# Altium schematic sheet Schematics.SchDoc
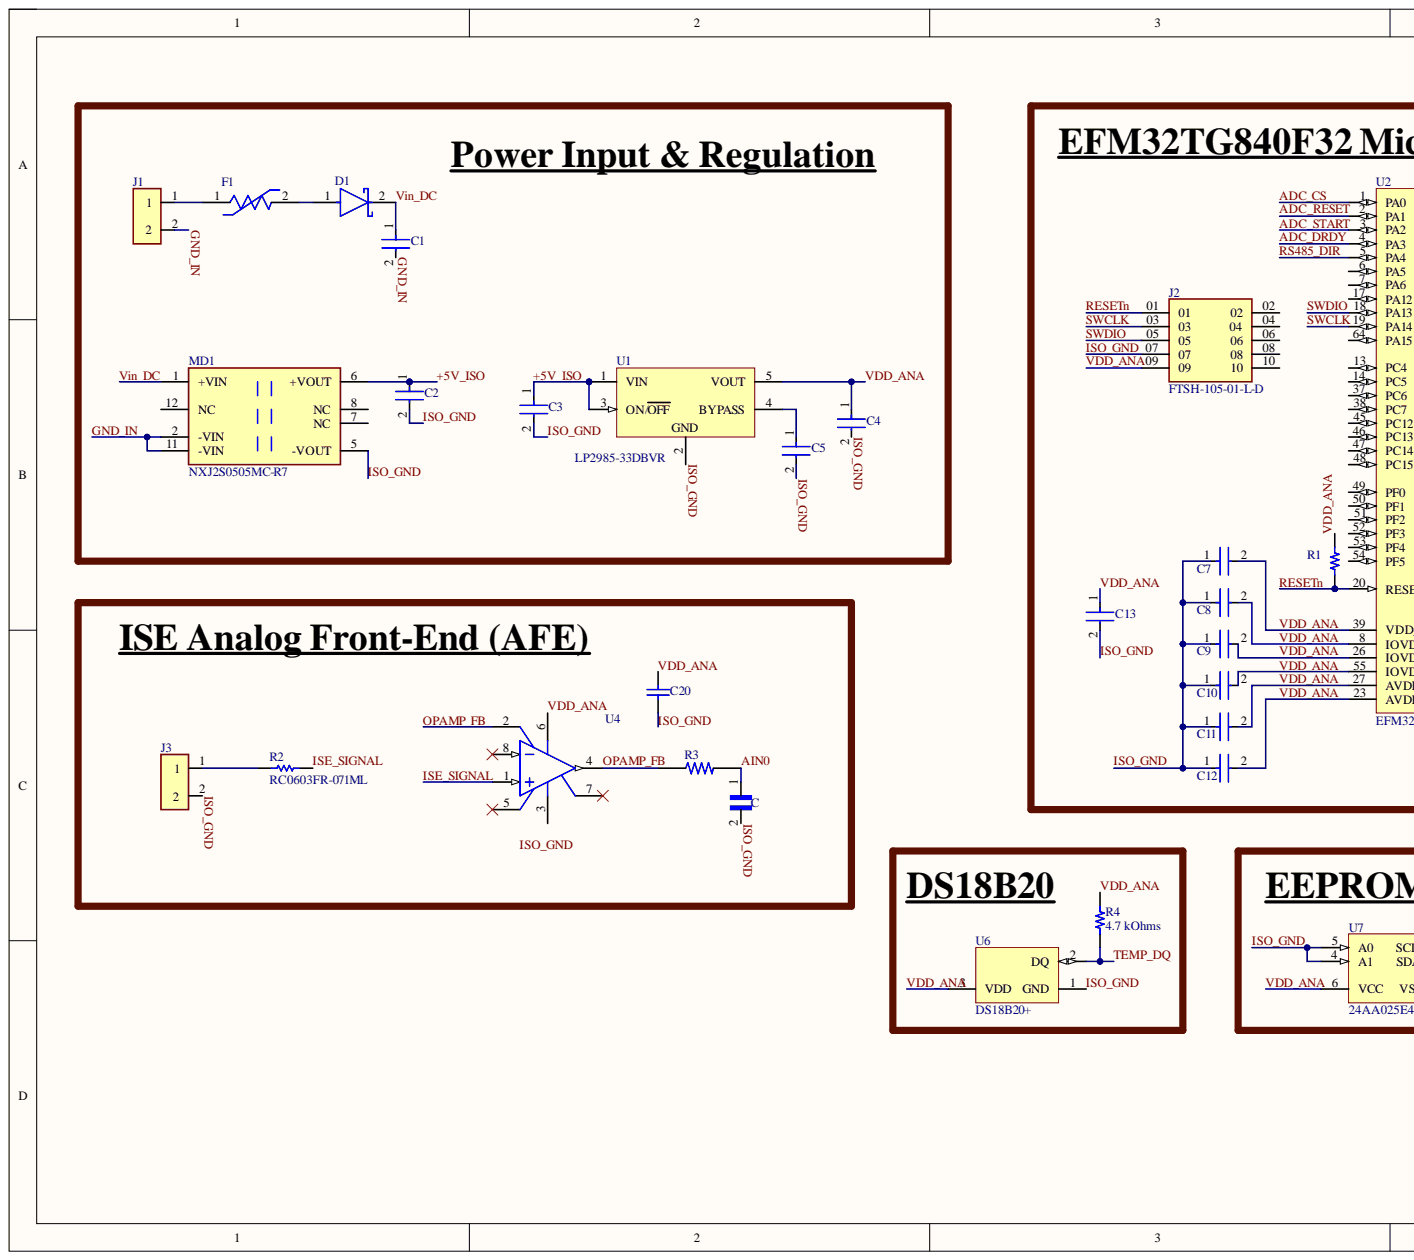
[diagram p0: Schematics.SchDoc, left]
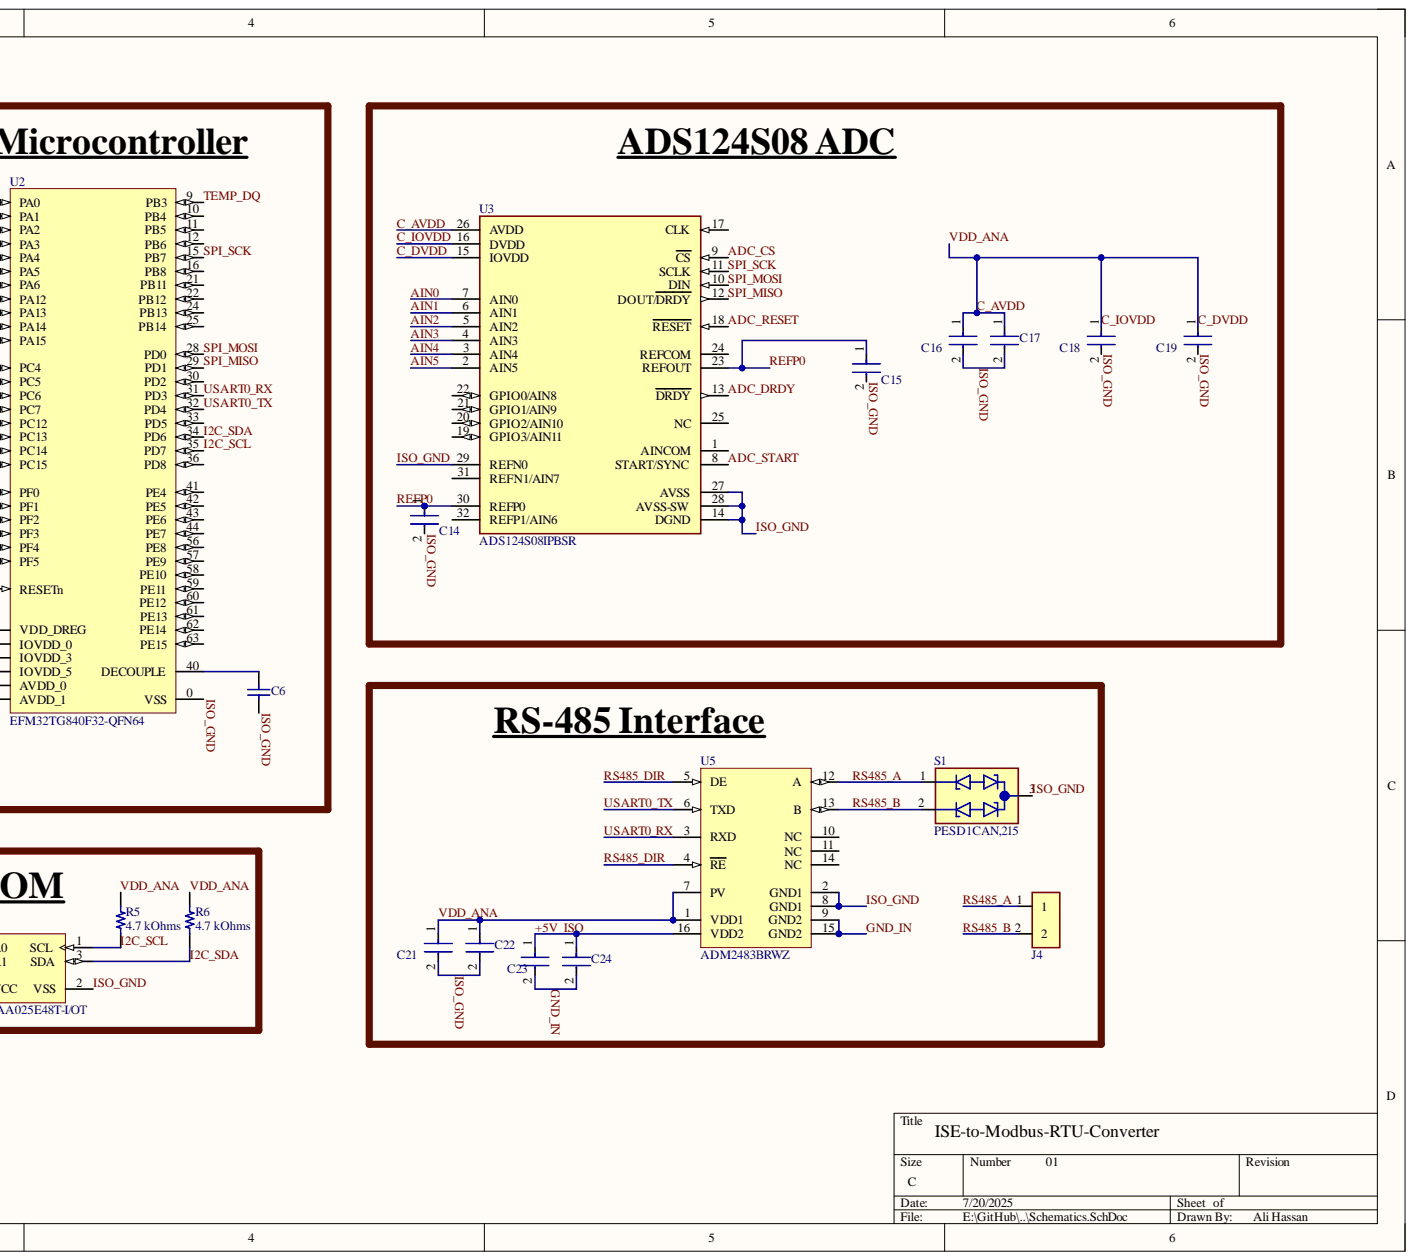
[diagram p1: Schematics.SchDoc, right]

## Parts
C  CL10B104KO8NNNC  [CAPC1608X90N]  (value not drawn)
C1  GRM188R60J106ME47D  [FP-GRM188-0_1-e0_2_0_55-IPC_A]  (value not drawn)
C2  GRM188R60J106ME47D  [FP-GRM188-0_1-e0_2_0_55-IPC_A]  (value not drawn)
C3  GRM188R60J106ME47D  [FP-GRM188-0_1-e0_2_0_55-IPC_A]  (value not drawn)
C4  GRM188R60J106ME47D  [FP-GRM188-0_1-e0_2_0_55-IPC_A]  (value not drawn)
C5  GRM188R60J106ME47D  [FP-GRM188-0_1-e0_2_0_55-IPC_A]  (value not drawn)
C6  C0805C105K4PACTU  [CAPC2013X145X50LL20T25]  (value not drawn)
C7  C1005X7R1H104K050BB  [FP-C1005-050-0_05-IPC_C]  (value not drawn)
C8  C1005X7R1H104K050BB  [FP-C1005-050-0_05-IPC_C]  (value not drawn)
C9  C1005X7R1H104K050BB  [FP-C1005-050-0_05-IPC_C]  (value not drawn)
C10  C1005X7R1H104K050BB  [FP-C1005-050-0_05-IPC_C]  (value not drawn)
C11  C1005X7R1H104K050BB  [FP-C1005-050-0_05-IPC_C]  (value not drawn)
C12  C1005X7R1H104K050BB  [FP-C1005-050-0_05-IPC_C]  (value not drawn)
C13  GRM188R60J106ME47D  [FP-GRM188-0_1-e0_2_0_55-IPC_A]  (value not drawn)
C14  C1005X7R1H104K050BB  [FP-C1005-050-0_05-IPC_C]  (value not drawn)
C15  C1005X7R1H104K050BB  [FP-C1005-050-0_05-IPC_C]  (value not drawn)
C16  C1005X7R1H104K050BB  [FP-C1005-050-0_05-IPC_C]  (value not drawn)
C17  GRM188R60J106ME47D  [FP-GRM188-0_1-e0_2_0_55-IPC_A]  (value not drawn)
C18  C1005X7R1H104K050BB  [FP-C1005-050-0_05-IPC_C]  (value not drawn)
C19  C1005X7R1H104K050BB  [FP-C1005-050-0_05-IPC_C]  (value not drawn)
C20  GRM188R71C104KA01D  [MURA-0603_H_0.9_M]  (value not drawn)
C21  C1005X7R1H104K050BB  [FP-C1005-050-0_05-IPC_C]  (value not drawn)
C22  GRM188R60J106ME47D  [FP-GRM188-0_1-e0_2_0_55-IPC_A]  (value not drawn)
C23  C1005X7R1H104K050BB  [FP-C1005-050-0_05-IPC_C]  (value not drawn)
C24  GRM188R60J106ME47D  [FP-GRM188-0_1-e0_2_0_55-IPC_A]  (value not drawn)
D1  SS14  [FP-403AE-MFG]  (value not drawn)
F1  1206L050YR  [FP-1206L050YR-MFG]  (value not drawn)
J1  OSTTC020162  [OST_OSTTC020162]  (value not drawn)
J2  FTSH-105-01-L-D  [SAMTEC_FTSH-105-01-L-D]
J3  OSTTC020162  [OST_OSTTC020162]  (value not drawn)
J4  OSTTC020162  [OST_OSTTC020162]  (value not drawn)
MD1  NXJ2S0505MC-R7  [FP-NXJ2SM-MFG]
R1  10 kOhms  [YAGEO RES 0603_1608]  (value not drawn)
R2  RC0603FR-071ML  [RESC1608X55X25LL10T15]
R3  RC0603FR-07100RL  [RESC1607X60N]  (value not drawn)
R4  4.7 kOhms  [YAGEO RES 0603_1608]
R5  4.7 kOhms  [YAGEO RES 0603_1608]
R6  4.7 kOhms  [YAGEO RES 0603_1608]
S1  PESD1CAN,215  [DIO_PESD1CAN,215]
U1  LP2985-33DBVR  [DBV0005A_M]
U2  EFM32TG840F32-QFN64  [ENMR-QFN64_N]
U3  ADS124S08IPBSR  [FP-PBS0032A-MFG]
U4  LMP7721MA/NOPB  [D0008A_M]  (value not drawn)
U5  ADM2483BRWZ  [ADI-ADM2483BRWZ-16_M]
U6  DS18B20+  [Q3+1]
U7  24AA025E48T-I/OT  [MCHP-SOT-23-OT6_L]

## Nets
+5V_ISO: C2.1 C3.1 C23.1 C24.1 MD1.6(+VOUT) U1.1(VIN) U1.3(ON/OFF) U5.16(VDD2)
ADC_CS: U2.1(PA0) U3.9(CS)
ADC_DRDY: U2.4(PA3) U3.13(DRDY)
ADC_RESET: U2.2(PA1) U3.18(RESET)
ADC_START: U2.3(PA2) U3.8(START/SYNC)
AIN0: C.1 R3.2 U3.7(AIN0)
AIN1: U3.6(AIN1)
AIN2: U3.5(AIN2)
AIN3: U3.4(AIN3)
AIN4: U3.3(AIN4)
AIN5: U3.2(AIN5)
C_AVDD: C4.1 C7.2 C8.2 C9.2 C10.2 C11.2 C12.2 C13.1 C16.1 C17.1 C18.1 C19.1 C20.2 C21.1 C22.1 J2.09 R1.2 R4.2 R5.2 R6.2 U1.5(VOUT) U2.8(IOVDD_0) U2.23(AVDD_1) U2.26(IOVDD_3) U2.27(AVDD_0) U2.39(VDD_DREG) U2.55(IOVDD_5) U3.15(IOVDD) U3.16(DVDD) U3.26(AVDD) U4.6 U5.1(VDD1) U5.7(PV) U6.3 U7.6(VCC)
GND_IN: C1.2 C23.2 C24.2 J1.2 MD1.2(-VIN) MD1.11(-VIN) U5.9(GND2) U5.15(GND2)
I2C_SCL: R5.1 U2.35(PD7) U7.1(SCL)
I2C_SDA: R6.1 U2.34(PD6) U7.3(SDA)
ISE_SIGNAL: R2.2 U4.1
ISO_GND: C.2 C2.2 C3.2 C4.2 C5.2 C6.1 C7.1 C8.1 C9.1 C10.1 C11.1 C12.1 C13.2 C14.2 C15.2 C16.2 C17.2 C18.2 C19.2 C20.1 C21.2 C22.2 J2.07 J3.2 MD1.5(-VOUT) S1.3 U1.2 U2.0(VSS) U3.14(DGND) U3.27(AVSS) U3.28(AVSS-SW) U3.29(REFN0) U5.2(GND1) U5.8(GND1) U6.1 U7.2(VSS) U7.4(A1) U7.5(A0)
OPAMP_FB: R3.1 U4.2 U4.4
REFP0: C14.1 C15.1 U3.23(REFOUT) U3.30(REFP0)
RESETn: J2.01 R1.1 U2.20(RESETn)
RS485_A: J4.1 S1.1 U5.12(A)
RS485_B: J4.2 S1.2 U5.13(B)
RS485_DIR: U2.5(PA4) U5.4(RE) U5.5(DE)
SPI_MISO: U2.29(PD1) U3.12(DOUT/DRDY)
SPI_MOSI: U2.28(PD0) U3.10(DIN)
SPI_SCK: U2.15(PB7) U3.11(SCLK)
SWCLK: J2.03 U2.19(PA14)
SWDIO: J2.05 U2.18(PA13)
TEMP_DQ: R4.1 U2.9(PB3) U6.2
USART0_RX: U2.31(PD3) U5.3(RXD)
USART0_TX: U2.32(PD4) U5.6(TXD)
Vin_DC: C1.1 D1.2 MD1.1(+VIN)
NetC5_1: C5.1 U1.4(BYPASS)
NetC6_2: C6.2 U2.40(DECOUPLE)
NetD1_1: D1.1 F1.2
NetF1_1: F1.1 J1.1
NetJ3_1: J3.1 R2.1

Not connected: J2 02 04 06 08 10; MD1 7(NC) 8(NC) 12(NC); U2 6(PA5) 7(PA6) 10(PB4) 11(PB5) 12(PB6) 13(PC4) 14(PC5) 16(PB8) 17(PA12) 21(PB11) 22(PB12) 24(PB13) 25(PB14) 30(PD2) 33(PD5) 36(PD8) 37(PC6) 38(PC7) 41(PE4) 42(PE5) 43(PE6) 44(PE7) 45(PC12) 46(PC13) 47(PC14) 48(PC15) 49(PF0) 50(PF1) 51(PF2) 52(PF3) 53(PF4) 54(PF5) 56(PE8) 57(PE9) 58(PE10) 59(PE11) 60(PE12) 61(PE13) 62(PE14) 63(PE15) 64(PA15); U3 1(AINCOM) 17(CLK) 19(GPIO3/AIN11) 20(GPIO2/AIN10) 21(GPIO1/AIN9) 22(GPIO0/AIN8) 24(REFCOM) 25(NC) 31(REFN1/AIN7) 32(REFP1/AIN6); U4 3 5 7 8; U5 10(NC) 11(NC) 14(NC)

## Library data of the parts
C = CL10B104KO8NNNC: 0.1ÂµF Â±10% 16V Ceramic Capacitor X7R 0603 _1608 Metric_; MF: Samsung Electro-Mechanics; Availability: Unavailable; Component Kind: Standard; Library Name: CL10B104KO8NNNC.IntLib; MP: CL10B104KO8NNNC; Package: 0603 Samsung; Price: None; SnapEDA_Link: https://www.snapeda.com/parts/CL10B104KO8NNNC/Samsung%20Electro-Mechanics/view-part/3598551/?ref=snap
C1-C5 C13 C17 C22 C24 = CMP-2006-02792-2: Chip Multilayer Ceramic Capacitors for General Purpose, 0603, 10uF, X5R, 15%, 20%, 6.3V; Capacitance: 10uF; Case Code (Imperial): 0603; Case Code (Metric): 1608; Case/Package: 0603; Component Kind: Standard; Depth: 0.8mm; Dielectric: X5R; Height: 0.8mm; Lead Free: Lead Free; Length: 1.6mm; Library Name: Altium Content Vault; Material: Ceramic; Max Operating Temperature: 85°C; Min Operating Temperature: -55°C; Mount: Surface Mount; Package Quantity: 4000; Packaging: Tape and Reel; Radiation Hardening: No; REACH SVHC: No SVHC; Termination: SMD/SMT; Thickness: 0.035inch; Tolerance: 20%; Voltage: 6.3V; Voltage Rating: 6.3V; Voltage Rating (DC): 6.3V; Width: 0.031inch
C6 = CMP-1036-04746-2: Manufacturer: Kemet; Component Kind: Standard; Library Name: Altium Content Vault; Part Number: C0805C105K4PACTU
C7-C12 C14-C16 C18 C19 C21 C23 = CMP-2000-05393-2: Multilayer Ceramic Capacitors 100nF ±10% 50V X7R SMD 0402; Capacitance: 100nF; Case Code (Imperial): 0402; Case Code (Metric): 1005; Case/Package: 0402; Component Kind: Standard; Construction: Flat; Depth: 0.5mm; Dielectric: X7R; Dielectric Material: Ceramic; Height: 0.5mm; Lead Free: Lead Free; Length: 1mm; Library Name: Altium Content Vault; Max Operating Temperature: 125°C; Min Operating Temperature: -55°C; Mount: Surface Mount; Package Quantity: 1; Packaging: Tape and Reel; Radiation Hardening: No; REACH SVHC: No SVHC; Resistor Type: Ceramic; RoHS Compliant: Yes; Series: C; Termination: SMD/SMT; Thickness: 0.022inch; Tolerance: 10%; Voltage: 50V; Voltage Rating: 50V; Voltage Rating (DC): 50V; Weight: 2.3E-05oz; Width: 0.02inch
C20 = CMP-2000-05253-1: CAP, Ceramic, 0.1 uF, 10%, 16 V, 0603, RoHS, Tape and Reel; Manufacturer: Murata; Brand: MurataElectronics; Capacitance: 0.1uF; Capacitance - nF: 100nF; Capacitance - pF: 100000pF; Case Height: 0.8mm; Case Length: 1.6mm; Dielectric: X7R; Temperature Coefficient / Code: +/-15%; Type: GeneralPurposeMLCC; Voltage Rating: 16V; Case Code - in: 0603; Case Code - mm: 1608; Case Width: 0.8mm; Component Kind: Standard; Datasheet URL: https://datasheet.ciiva.com/29202/451467-da-01-en-vs-kondensator-0603-29202287.pdf; Datasheet Version: Dec-10; Dimensions: 0.8mmWx1.6mmLx0.8mmH; Factory Pack Quantity: 4000; Library Name: Altium Content Vault; Manufacturer URL: http://www.murata.com/; Maximum Operating Temperature: +125C; Minimum Operating Temperature: -55C; Operating Temperature Range: -55Cto+125C; Package / Case: 0603(1608metric); Packaging: Reel; Product: GeneralTypeMLCCs; Product Category: MultilayerCeramicCapacitorsMLCC-SMD/SMT; RoHS: Details; Series: GRM; Termination Style: SMD/SMT; Tolerance: 10%; Voltage Rating DC: 16V
D1 = CMP-2000-04943-2: DIODE SCHOTTKY 40V 1A SMA; Manufacturer: ON Semiconductor; Max Junction Temp: +125°C; Max Surge Forward Current: 40A; Peak Reverse Current: 0.2mA; Standoff Height: 0.05mm; Automotive: No; Category: Diode; Component Kind: Standard; ComponentLink2Description: Datasheet; ComponentLink2URL: https://www.onsemi.com/pub/Collateral/SS19-D.PDF; Device Class L1: Discrete Semiconductors; Device Class L2: Diodes; Device Class L3: Schottky Diodes; Digikey Description: DIODE SCHOTTKY 40V 1A SMA; Forward Current: 1A; Height: 2.5mm; Lead Free: Yes; Library Name: Altium Content Vault; Manufacturer Part Number: SS14; Max Repetitive Reverse Voltage: 40V; Mouser Description: Schottky Diodes & Rectifiers SMA 40V 1.0A; Octopart: https://octopart.com/ss14-on+semiconductor-29205033; Package: 403AE; RoHS: TRUE; Temperature: -65°C to +125°C; Temperature Range High: +125°C; Temperature Range Low: -65°C
F1 = CMP-08606-000073-1: PTC RESET FUSE 6V 500MA 1206; Approvals: cULus; Current Rating: 100A; Max Power Dissipation: 600mW; Resistance: 700mR; Time to Trip: 0.1s; Trip Current: 1A; Case Code (Imperial): 1206; Case Code (Metric): 3216; Case/Package: 1206; Component Kind: Standard; Height: 0.75mm; Hold Current: 500mA; Lead Free: Lead Free; Length: 3.4mm; Library Name: Altium Content Vault; Max Current Rating: 100A; Max Operating Temperature: 85°C; Max Voltage Rating (DC): 6V; Min Operating Temperature: -40°C; Operating Supply Voltage: 6V; Package Quantity: 4000; Packaging: Tape and Reel; Power Dissipation: 600mW; REACH SVHC: No SVHC; RoHS Compliant: Yes; Series: 1206L; Terminal Material: Copper; Termination: SMD/SMT; Voltage Rating: 6V; Voltage Rating (DC): 6V; Width: 1.8mm; Working Voltage: 6V
J1 J3 J4 = OSTTC020162: 2 Position Wire to Board Terminal Block Horizontal with Board 0.197 _5.00mm_ Through Hole; MF: On Shore; Availability: Unavailable; Component Kind: Standard; Library Name: OSTTC020162.IntLib; MP: OSTTC020162; Package: None; Price: None; SnapEDA_Link: https://www.snapeda.com/parts/OSTTC020162/On%20Shore%20Technology%20Inc./view-part/13090/?ref=snap
J2 = FTSH-105-01-L-D: MF: Samtec; Availability: Unavailable; Component Kind: Standard; Library Name: FTSH-105-01-L-D.IntLib; MP: FTSH-105-01-L-D; Package: None; Price: None
MD1 = CMP-20596-000009-1: DC DC CONVERTER 5V 2W; Component Kind: Standard; Library Name: Altium Content Vault; RoHS Compliant: Yes
R1 = AA0603FR-0710KL: RES SMD 10K OHM 1% 1/10W 0603; Manufacturer: Yageo; Database Table Name: Resistors - Surface Mount - 0603; Power (Watts): 0.1W, 1/10W; Temperature Coefficient: ±150ppm/°C; Component Kind: Standard; ComponentLink1Description: Datasheet; ComponentLink1URL: https://www.yageo.com/upload/media/product/productsearch/datasheet/rchip/PYu-AA_51_RoHS_L_3.pdf; ComponentLink2Description: DigiKey Link; ComponentLink2URL: https://www.digikey.com/en/products/detail/yageo/AA0603FR-0710KL/5888698; Composition: Thick Film; Device Package: 0603 (1608 Metric); Features: Anti-Sulfur, Automotive AEC-Q200, Moisture Resistant; Height - Seated (Max): 0.022" (0.55mm); LastUpdated: 25/07/2024 9:19:15 am; Library Name: Celestial Altium Library - altium_library.DbLib; Lifecycle Status: Active; Minimum Order: 1; Number of Terminations: 2; Operating Temperature: -55°C ~ 155°C; Package / Case: 0603 (1608 Metric); Packaging: TapeAndReel; Part Number: AA0603FR-0710KL; Part Status: Active; PartId: 64302; Price: 0.01; Ratings: AEC-Q200; Resistance: 10 kOhms; Series: AA; Size / Dimension: 0.063" L x 0.031" W (1.60mm x 0.80mm); Supplier 1: DigiKey; Supplier Device Package: 0603; Supplier Part Number 1: 13-AA0603FR-0710KLCT-ND; Tolerance: ±1%; Value: 10 kOhms
R2 = CMP-1659-00056-1: Chip Resistor, 1 MOhm, +/- 1%, 100 mW, -55 to 155 degC, 0603 (1608 Metric), RoHS, Tape and Reel; Capacitance: 1 mF; Case\Package: Radial; Tolerance: 20%; Voltage Rating: 25 V; Component Kind: Standard; ComponentLink1Description: Manufacturer URL; ComponentLink1URL: http://www.yageo.com/; ComponentLink2Description: Datasheet; ComponentLink2URL: http://www.yageo.com/documents/recent/PYu-RC_Group_51_RoHS_L_04.pdf; DatasheetVersion: Rev. 4, 02/2016; Diameter: 10 mm; Height: 20 mm; Lead Pitch: 5 mm; Length: 20 mm; Library Name: Altium Content Vault; Max Operating Temperature: 85 degC; Min Operating Temperature: -40 degC; Mount: Through Hole; Package Quantity: 200; PackageDescription: 2-Pin Surface Mount Device, Body 1.6 x 0.8 mm; PackageReference: 0603; Packaging: Bulk; Radiation Hardening: No; REACH SVHC: No SVHC; RoHSCompliant: true; Voltage Rating (DC): 25 V; Width: 0 inch
R3 = RC0603FR-07100RL: 100 Ohms Â±1% 0.1W, 1/10W Chip Resistor 0603 (1608 Metric) Moisture Resistant Thick Film; MF: Yageo; Check_prices: https://www.snapeda.com/parts/RC0603FR-07100RL/Yageo/view-part/?ref=eda; Component Kind: Standard; Library Name: RC0603FR-07100RL.IntLib; MP: RC0603FR-07100RL; Package: 0603 Yageo; SnapEDA_Link: https://www.snapeda.com/parts/RC0603FR-07100RL/Yageo/view-part/?ref=snap
R4-R6 = AC0603FR-074K7L: RES SMD 4.7K OHM 1% 1/10W 0603; Manufacturer: Yageo; Database Table Name: Resistors - Surface Mount - 0603; Power (Watts): 0.1W, 1/10W; Temperature Coefficient: ±100ppm/°C; Component Kind: Standard; ComponentLink1Description: Datasheet; ComponentLink1URL: https://www.yageo.com/upload/media/product/productsearch/datasheet/rchip/PYu-AC_51_RoHS_L_7.pdf; ComponentLink2Description: DigiKey Link; ComponentLink2URL: https://www.digikey.com/en/products/detail/yageo/AC0603FR-074K7L/5896160; Composition: Thick Film; Device Package: 0603 (1608 Metric); Features: Automotive AEC-Q200, Moisture Resistant; Height - Seated (Max): 0.022" (0.55mm); LastUpdated: 25/07/2024 9:19:24 am; Library Name: Celestial Altium Library - altium_library.DbLib; Lifecycle Status: Active; Minimum Order: 1; Number of Terminations: 2; Operating Temperature: -55°C ~ 155°C; Package / Case: 0603 (1608 Metric); Packaging: CutTape; Part Number: AC0603FR-074K7L; Part Status: Active; PartId: 17355; Price: 0.01; Ratings: AEC-Q200; Resistance: 4.7 kOhms; Series: AC; Size / Dimension: 0.063" L x 0.031" W (1.60mm x 0.80mm); Supplier 1: DigiKey; Supplier Device Package: 0603; Supplier Part Number 1: YAG3613CT-ND; Tolerance: ±1%; Value: 4.7 kOhms
S1 = PESD1CAN,215: 70V Clamp 3A (8/20Âµs) Ipp Tvs Diode Surface Mount TO-236AB; MF: Nexperia USA Inc.; Check_prices: https://www.snapeda.com/parts/PESD1CAN,215/Nexperia/view-part/?ref=eda; Component Kind: Standard; Library Name: PESD1CAN_215.IntLib; MP: PESD1CAN,215; Package: SC-59 Nexperia USA; SnapEDA_Link: https://www.snapeda.com/parts/PESD1CAN,215/Nexperia/view-part/?ref=snap
U1 = CMP-0758-00080-2: Single Output Low Noise LDO, 150 mA, Fixed 3.3 V Output, 1.5% Tolerance, 2.2 to 16 V Input, Low IQ, 5-Pin SOT-23 (DBV), -40 to 125 degC, Green (RoHS & no Sb/Br), Tape and Reel; Manufacturer: Texas Instruments; Accuracy (%): 1.5; Fixed Output Options (V): 3.3; Iout (Max) (A): 0.15; Iq (Typ) (mA): 0.85; Output Options: Fixed Output; Vdo (Typ) (mV): 280; Component Kind: Standard; ComponentLink1Description: Manufacturer URL; ComponentLink1URL: http://www.ti.com/; ComponentLink2Description: Datasheet; ComponentLink2URL: http://www.ti.com/lit/ds/symlink/lp2985-50.pdf; ComponentLink3Description: Package Specification; ComponentLink3URL: http://www.ti.com/litv/pdf/mpds018j; DatasheetVersion: SLVS522N; Library Name: Altium Content Vault; Mounting Technology: Surface Mount; Operating Temperature Range (degC): -40 to 125; PackageDescription: 5-Pin Plastic Small Outline, Body 2.9 x 1.6 mm, Pitch 0.95 mm; PackageReference: DBV0005A; PackageVersion: 4073253-4/L; Packing: Tape and Reel; PartNumber: LP2985-33DBVR; RoHS: TRUE; Vin (V): 2.2 to 16
U2 = CMP-0270-00147-1: EFM32 Tiny Gecko, M3 ARM Cortex CPU, 32 KB Flash, 4 KB RAM, 1.85 - 3.8 V Supply, 32 MHz Max Speed, -40 to 85 degC, 64-Pin QFN, RoHS Compliant; ADC: Y; Flash (KB): 32; GPIO: 56; I2C: 1; LCD: 8x20; OPAMPS: 3; RAM (KB): 4; USART/SPI (I2S): 2; AES: Y; ARM Cortex CPU: M3; Component Kind: Standard; ComponentLink1Description: Manufacturer URL; ComponentLink1URL: http://www.energymicro.com/; ComponentLink2Description: Datasheet; ComponentLink2URL: http://cdn.energymicro.com/dl/devices/pdf/d0011_efm32tg840_datasheet.pdf; ComponentLink3Description: Package Specification; ComponentLink3URL: http://cdn.energymicro.com/dl/devices/pdf/d0005_efm32g230_datasheet.pdf; DatasheetVersion: Rev 1.00; Generic Part Number: EFM32TG840; LESENSE: Y; Library Name: Altium Content Vault; Max Speed (MHz): 32; Mounting Technology: Surface Mount; PackageDescription: 64-Pin Quad Flat No-Lead, Body 9 x 9 mm, Pitch 0.5 mm; PackageReference: QFN64; PackageVersion: Rev. 98SPP64048A_XO1_08MAR2011; PartNumber: EFM32TG840F32-QFN64; Subcategory: EFM32 Tiny Gecko; Supply Voltage (V): 1.85 - 3.8
U3 = CMP-04860-000020-1: IC ADC 24BIT SIGMA-DELTA 32LQFP; Interface: SPI; Number of A/D Converters: 1; Number of Bits: 24; Number of Inputs: 12; Sampling Rate: 4000S/s; Case/Package: LQFP; Component Kind: Standard; Library Name: Altium Content Vault; Max Operating Temperature: 125°C; Min Operating Temperature: -50°C; Mount: Surface Mount; Number of Pins: 32; Packaging: Tape and Reel; RoHS Compliant: Yes
U4 = CMP-0055-00395-3: 3 Femtoampere Input Bias Current Precision Amplifier, 8-pin Narrow SOIC, Pb-Free; Manufacturer: Texas Instruments; CompType: Amplifiers; PackageTopMarking: NSZXTT*LMP77*21MA; PowerWise: Y; Code_IPC: SOIC127P600-8; Code_JEDEC: MS-012-AA; Component Kind: Standard; ComponentLink1Description: Datasheet URL; ComponentLink1URL: http://www.ti.com/general/docs/lit/getliterature.tsp?genericPartNumber=LMP7721&fileType=pdf; Library Name: Altium Content Vault; Package Reference: D0008A; PackageDescription: SOIC Narrow, 4.9 x 3.9 x 1.45mm, 8 Lead, 1.27mm Pitch; PinCount: 8; RoHS: Y
U5 = CMP-2000-05041-1: Half-Duplex, iCoupler(R) Isolated RS-485 Transceiver , 500 Kbps , -40 to 85 degC, 16-Pin SOIC (RW-16), RoHS, Tube; Manufacturer: Analog Devices; Data Rate: 0.5kbps; Data Transmission Topology: Multipoint; DELETED: Compliant; Dual Supply Voltage (Max): 5.25/5.5V; Dual Supply Voltage (Min): 2.7/4.75V; Dual Supply Voltage (Typ): 3.3/5V; Interface Circuit Standard 1: EIA/TIA-485-A/RS-485; Number of Receivers: 1; Power Supply Requirement: Dual; Rad Hardened: No; Receiver Signal Type: Differential; Single Supply Voltage (Max): NotRequiredV; Supply Current: 2.5mA; Component Kind: Standard; Datasheet URL: https://datasheet.ciiva.com/19456/2169766-19456772.pdf; Datasheet Version: Rev. B, 03/2005; Library Name: Altium Content Vault; Manufacturer URL: http://www.analog.com/; Mounting: SurfaceMount; Number of Transceivers: 1; Number of Transmitters: 1; Operating Temp Range: -40Cto85C; Operating Temperature Classification: Industrial; Package Type: SOICW; Packaging: Rail/Tube; Pin Count: 16; Single Supply Voltage (Min): NotRequiredV; Single Supply Voltage (Typ): NotRequiredV; Transmitter Signal Type: Differential
U6 = CMP-0253-00003-1: Programmable Resolution Digital Thermometer, 1-Wire Interface, ±0.5°C Accuracy, 3-Pin TO92, -55°C to +125°C, Pb-Free; Code_JEDEC: TO-226-AA; Component Kind: Standard; ComponentLink1Description: Manufacturer URL; ComponentLink1URL: http://www.maxim-ic.com; ComponentLink2Description: Datasheet; ComponentLink2URL: http://datasheets.maxim-ic.com/en/ds/DS18B20.pdf; DatasheetVersion: 2007; Library Name: Altium Content Vault; PackageDescription: TO-92 Package, Body Dia. 4.7mm; Leads 0.51 x 0.51 mm (max), Pb-Free; PackageReference: Q3+1; PackageVersion: revB, 2009
U7 = CMP-0159-01033-1: 2K bits I2C™ Serial EEPROM with EUI-48™ Node Identity, 1.7 to 5.5 V, 400 kHz, -40 to 85 degC, 6-pin SOT-23 (OT6), Tape and Reel; Manufacturer: Microchip; Component Kind: Standard; ComponentLink1Description: Manufacturer URL; ComponentLink1URL: http://www.microchip.com; ComponentLink2Description: Datasheet; ComponentLink2URL: http://ww1.microchip.com/downloads/en/DeviceDoc/22124D.pdf; ComponentLink3Description: Package Specification; ComponentLink3URL: http://www.microchip.com/stellent/groups/techpub_sg/documents/packagingspec/en012702.pdf; ComponentLink4Description: Product URL; ComponentLink4URL: http://www.microchip.com/24AA025E48; DatasheetVersion: Rev. D; Library Name: Altium Content Vault; Mounting Technology: Surface Mount; PackageDescription: 6-Lead Plastic Small Outline Transistor, 2.9 x 1.55 mm Body, 0.95 mm Pitch; PackageReference: SOT-23-OT6; PackageVersion: C04-028B; PartNumber: 24AA025E48T-I/OT
